# Altium schematic sheet 3A03xx_Oxocard_Mini_Basic_SENSORS.SchDoc
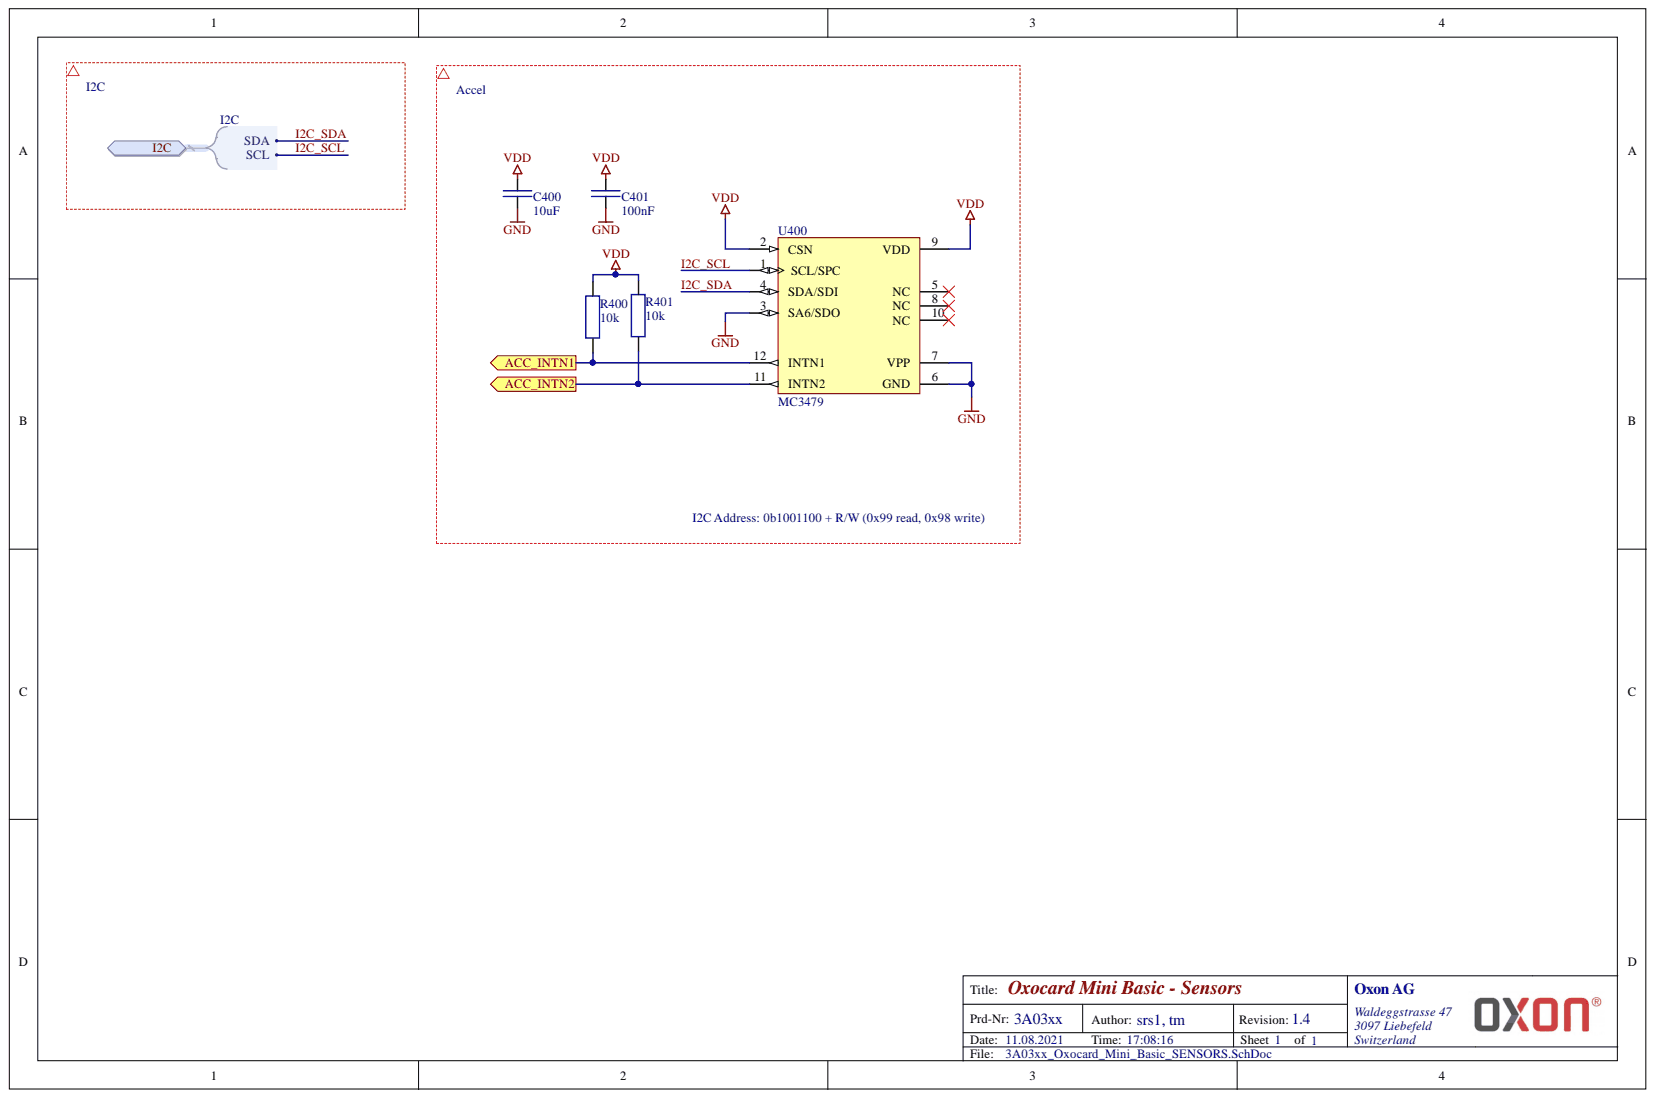
[diagram p0: 3A03xx_Oxocard_Mini_Basic_SENSORS.SchDoc]

## Parts
C400  10uF  [CAPC1005X60]
C401  100nF  [CAPC1005X60]
R400  10k  [RESC1005X40]
R401  10k  [RESC1005X40]
U400  MC3479  [LGA-12]

## Ports
ACC_INTN1, ACC_INTN2, I2C, I2C.SCL, I2C.SDA

## Nets
GND: C400.1 C401.1 U400.3(SA6/SDO) U400.6(GND) U400.7(VPP)
VDD: C400.2 C401.2 R400.2 R401.2 U400.2(CSN) U400.9(VDD)
ACC_INTN1: R400.1 U400.12(INTN1) ; port ACC_INTN1
ACC_INTN2: R401.1 U400.11(INTN2) ; port ACC_INTN2
I2C_SCL: U400.1(SCL/SPC) ; port I2C
I2C_SDA: U400.4(SDA/SDI) ; port I2C

Not connected: U400 5(NC) 8(NC) 10(NC)

## Library data of the parts
C400 = C-CAP0402_10u: SMD capacitor 6.3V 20%; Manufacturer: Wurth Electronics; Manufacturer Designator: ZRB15XR60J106ME2D; Component Kind: Standard; Distributor: Mouser; Distributor Part-Number: 81-ZRB15XR60J106ME2D; Library Name: XLib.SCHLIB
C401 = C-CAP0402_100n: SMD capacitor 10V 5%; Manufacturer: Wurth Electronics; Manufacturer Designator: GRM155R61A104JA1D; Component Kind: Standard; Distributor: Mouser; Distributor Part-Number: 81-GRM155R61A104JA1D; Library Name: XLib.SCHLIB
R400 R401 = R-RES0402_10k: SMD resistor 1%; Manufacturer: Yageo; Manufacturer Designator: RC0402FR-0710KL; Component Kind: Standard; Distributor: Mouser; Distributor Part-Number: 603-RC0402FR-0710KL; Library Name: XLib.SCHLIB
U400 = U-MC3479: 3 axis accelerometer 1.7V-3.6V; Manufacturer: MEMSIC; Component Kind: Standard; Distributor: Mouser; Distributor Part-Number: 498-MC3479; Library Name: XLib.SCHLIB; Manufacturer Designator: MC3479
